# Altium schematic sheet Schem, Transadmittance MPU_Rev_B.SchDoc
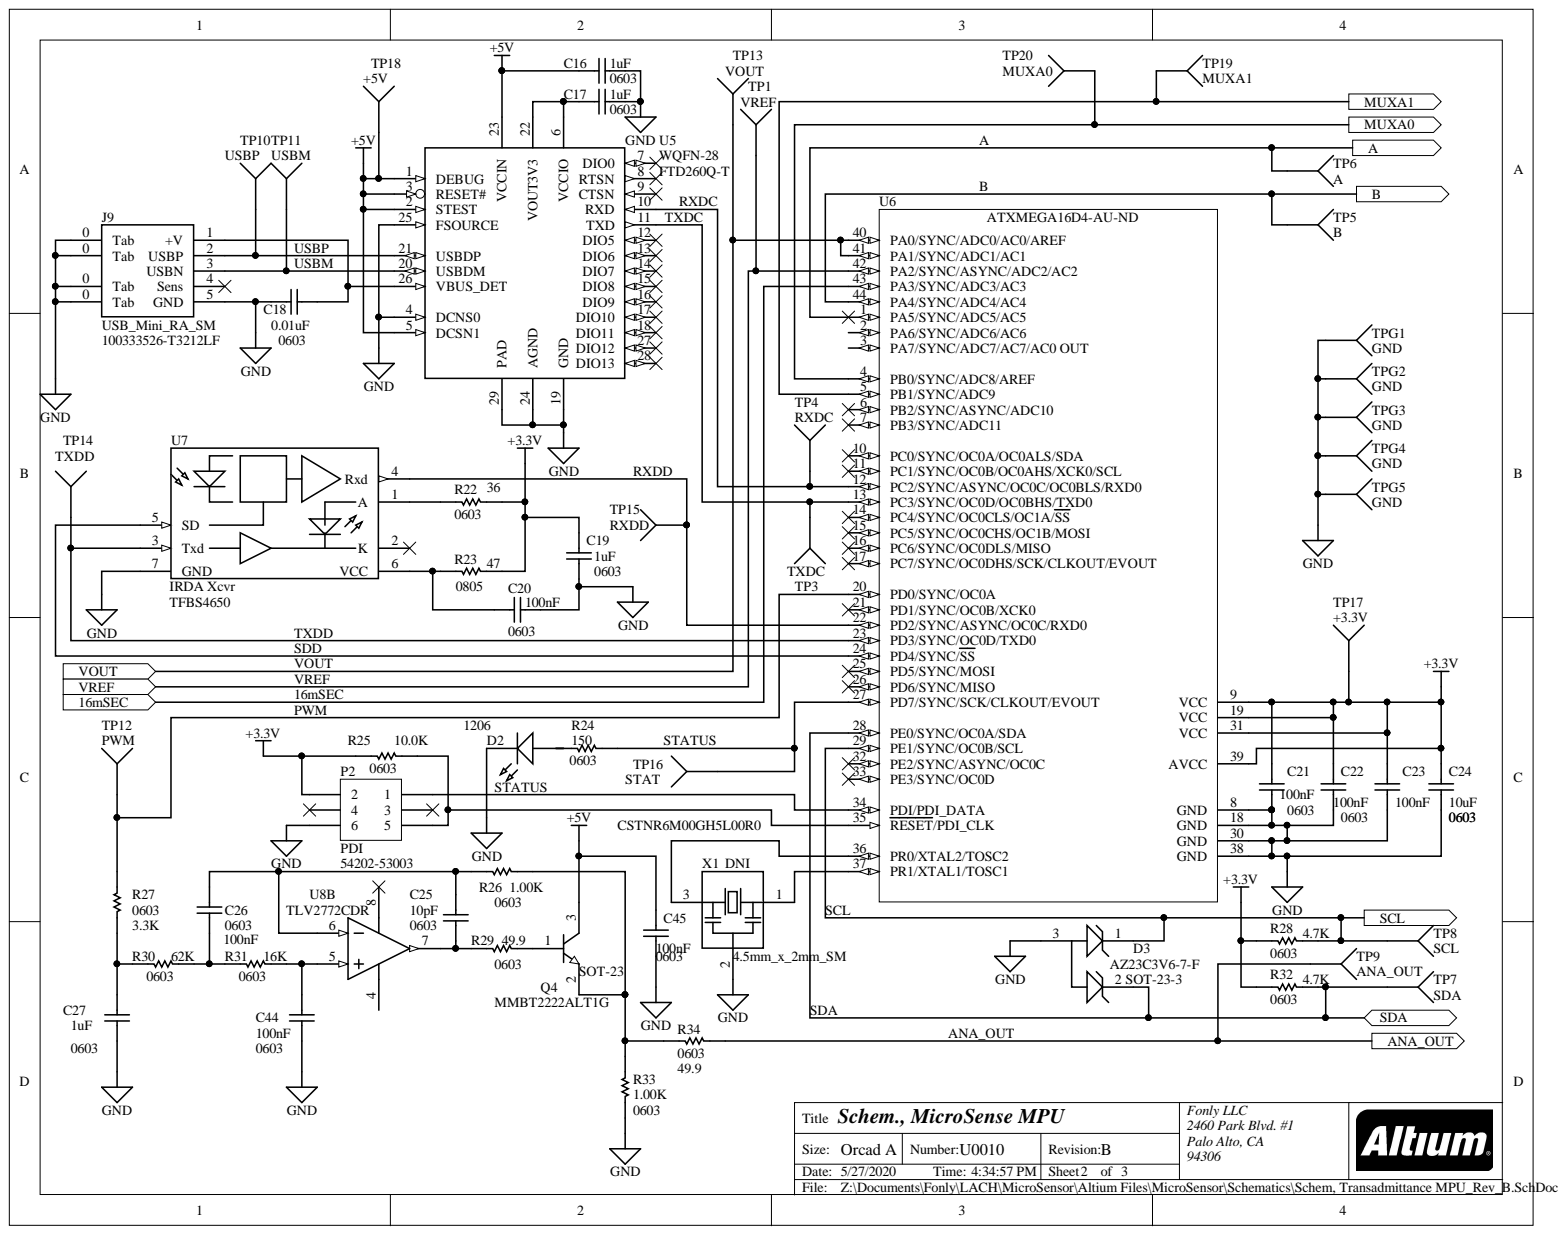
[diagram p0: Schem, Transadmittance MPU_Rev_B.SchDoc]

## Parts
C16  0603  value 1uF  [1608[0603]]
C17  0603  value 1uF  [1608[0603]]
C18  0603  value 0.01uF  [1608[0603]]
C19  0603  value 1uF  [1608[0603]]
C20  0603  value 100nF  [1608[0603]]
C21  0603  value 100nF  [1608[0603]]
C22  0603  value 100nF  [1608[0603]]
C23  0603  value 100nF  [1608[0603]]
C24  0603  value 10uF  [1608[0603]]
C25  0603  value 10pF  [1608[0603]]
C26  0603  value 100nF  [1608[0603]]
C27  0603  value 1uF  [1608[0603]]
C44  0603  value 100nF  [1608[0603]]
C45  0603  value 100nF  [1608[0603]]
D2  1206  value STATUS  [3.5X2.8X1.9]
D3  SOT-23-3  MFG_P/N: AZ23C3V6-7-F  [SOT-23_L]
J9  USB_Mini_RA_SM  MFG_P/N: 100333526-T3212LF  [USB-B-Mini_SM_RA]
P2  PDI  MFG_P/N: 54202-53003  [FCI54202]
Q4  SOT-23  MFG_P/N: MMBT2222ALT1G  [SOT-23_N]
R22  0603  value 36  [RESC1608L]
R23  0805  value 47  [6-0805_M]
R24  0603  value 150  [RESC1608L]
R25  0603  value 10.0K  [RESC1608L]
R26  0603  value 1.00K  [RESC1608L]
R27  0603  value 3.3K  [RESC1608L]
R28  0603  value 4.7K  [RESC1608L]
R29  0603  value 49.9  [RESC1608L]
R30  0603  value 62K  [RESC1608L]
R31  0603  value 16K  [RESC1608L]
R32  0603  value 4.7K  [RESC1608L]
R33  0603  value 1.00K  [RESC1608L]
R34  0603  value 49.9  [RESC1608L]
TP1  VREF  [PIN1]
TP3  TXDC  [PIN1]
TP4  RXDC  [PIN1]
TP5  B  [PIN1]
TP6  A  [PIN1]
TP7  SDA  [PIN1]
TP8  SCL  [PIN1]
TP9  ANA_OUT  [PIN1]
TP10  USBP  [PIN1]
TP11  USBM  [PIN1]
TP12  PWM  [PIN1]
TP13  VOUT  [PIN1]
TP14  TXDD  [PIN1]
TP15  RXDD  [PIN1]
TP16  STAT  [PIN1]
TP17  +3.3V  [PIN1]
TP18  +5V  [PIN1]
TP19  MUXA1  [PIN1]
TP20  MUXA0  [PIN1]
TPG1  GND  [PIN1]
TPG2  GND  [PIN1]
TPG3  GND  [PIN1]
TPG4  GND  [PIN1]
TPG5  GND  [PIN1]
U5  WQFN-28  MFG_P/N: FTD260Q-T  [WQFN-28]
U6  Vendor P/N: ATXMEGA16D4-AU-ND  [44A_N]  (hidden comment: ATxmega16D4-AU)
U7  IRDA Xcvr  [TFBS4650]
U8  TLV2772CDR  [D0008A_N]  (part B)
X1  4.5mm_x_2mm_SM  value DNI  [CSTNR]

## Ports
16mSEC, A, ANA_OUT, B, MUXA0, MUXA1, SCL, SDA, VOUT, VREF

## Nets
+3.3V: C19.1 C21.1 C22.1 C23.1 C24.1 P2.2 R22.1 R23.1 R25.1 R28.2 R32.2 TP17.1 U6.9(VCC) U6.19(VCC) U6.31(VCC) U6.39(AVCC)
+5V: C16.2 C45.1 Q4.3 TP18.1 U5.1(DEBUG) U5.2(STEST) U5.3(RESET#) U5.5(DCSN1) U5.23(VCCIN)
GND: C16.1 C17.2 C18.2 C19.2 C20.2 C21.2 C22.2 C23.2 C24.2 C27.2 C44.2 C45.2 D2.2 D3.3 J9.0(Tab) J9.5(GND) P2.6 R33.1 TPG1.1 TPG2.1 TPG3.1 TPG4.1 TPG5.1 U5.4(DCNS0) U5.19(GND) U5.24(AGND) U5.25(FSOURCE) U5.29(PAD) U6.8(GND) U6.18(GND) U6.30(GND) U6.38(GND) U7.7(GND) X1.2
16mSEC: U6.43(PA3/SYNC/ADC3/AC3) ; port 16mSEC
A: TP6.1 U6.1(PA5/SYNC/ADC5/AC5) ; port A
ANA_OUT: R34.1 TP9.1 ; port ANA_OUT
B: TP5.1 U6.44(PA4/SYNC/ADC4/AC4) ; port B
MUXA0: TP20.1 U6.4(PB0/SYNC/ADC8/AREF) ; port MUXA0
MUXA1: TP19.1 U6.5(PB1/SYNC/ADC9) ; port MUXA1
PWM: R27.2 TP12.1 U6.20(PD0/SYNC/OC0A)
RXDC: TP4.1 U5.10(RXD) U6.12(PC2/SYNC/ASYNC/OC0C/OC0BLS/RXD0)
RXDD: TP15.1 U6.22(PD2/SYNC/ASYNC/OC0C/RXD0) U7.4(Rxd)
SCL: D3.1 R28.1 TP8.1 U6.29(PE1/SYNC/OC0B/SCL) ; port SCL
SDA: D3.2 R32.1 TP7.1 U6.28(PE0/SYNC/OC0A/SDA) ; port SDA
SDD: U6.24(PD4/SYNC/SS) U7.5(SD)
STATUS: R24.2 TP16.1 U6.27(PD7/SYNC/SCK/CLKOUT/EVOUT)
TXDC: TP3.1 U5.11(TXD) U6.13(PC3/SYNC/OC0D/OC0BHS/TXD0)
TXDD: TP14.1 U6.23(PD3/SYNC/OC0D/TXD0) U7.3(Txd)
USBM: J9.3(USBN) TP11.1 U5.20(USBDM)
USBP: J9.2(USBP) TP10.1 U5.21(USBDP)
VOUT: TP13.1 U6.40(PA0/SYNC/ADC0/AC0/AREF) U6.41(PA1/SYNC/ADC1/AC1) ; port VOUT
VREF: TP1.1 U6.42(PA2/SYNC/ASYNC/ADC2/AC2) ; port VREF
NetC17_1: C17.1 U5.6(VCCIO) U5.22(VOUT3V3)
NetC18_1: C18.1 J9.1(+V) U5.26(VBUS_DET)
NetC20_1: C20.1 R23.2 U7.6(VCC)
NetC25_1: C25.1 C26.1 R26.2 U8.6
NetC25_2: C25.2 R29.2 U8.7
NetC26_2: C26.2 R30.1 R31.2
NetC27_1: C27.1 R27.1 R30.2
NetC44_1: C44.1 R31.1 U8.5
NetD2_1: D2.1 R24.1
NetP2_1: P2.1 U6.34(PDI/PDI_DATA)
NetP2_5: P2.5 R25.2 U6.35(RESET/PDI_CLK)
NetQ4_1: Q4.1 R29.1
NetQ4_2: Q4.2 R26.1 R33.2 R34.2
NetR22_2: R22.2 U7.1(A)
NetU6_36: U6.36(PR0/XTAL2/TOSC2) X1.3
NetU6_37: U6.37(PR1/XTAL1/TOSC1) X1.1

Not connected: J9 4(Sens); P2 3 4; U5 7(DIO0) 8(RTSN) 9(CTSN) 12(DIO5) 13(DIO6) 14(DIO7) 15(DIO8) 16(DIO9) 17(DIO10) 18(DIO11) 27(DIO12) 28(DIO13); U6 2(PA6/SYNC/ADC6/AC6) 3(PA7/SYNC/ADC7/AC7/AC0_OUT) 6(PB2/SYNC/ASYNC/ADC10) 7(PB3/SYNC/ADC11) 10(PC0/SYNC/OC0A/OC0ALS/SDA) 11(PC1/SYNC/OC0B/OC0AHS/XCK0/SCL) 14(PC4/SYNC/OC0CLS/OC1A/SS) 15(PC5/SYNC/OC0CHS/OC1B/MOSI) 16(PC6/SYNC/OC0DLS/MISO) 17(PC7/SYNC/OC0DHS/SCK/CLKOUT/EVOUT) 21(PD1/SYNC/OC0B/XCK0) 25(PD5/SYNC/MOSI) 26(PD6/SYNC/MISO) 32(PE2/SYNC/ASYNC/OC0C) 33(PE3/SYNC/OC0D); U7 2(K); U8 4 8

## Library data of the parts
C16 = Cap Semi: Capacitor Ceramic, SMD, 0603, .01uF, 16V, 10%; MFG: Kemet; MFG_P/N: C0603C105M3RACTU; Vendor: DigiKey; Vendor P/N: 399-7377-1-ND; Component Kind: Standard; Library Name: Miscellaneous Devices.SchLib; Signal Integrity: Cap Semi; Simulation: CAP
C17 C19 = Cap Semi: Capacitor (Semiconductor SIM Model); MFG: Kemet; MFG_P/N: C0603C105M3RACTU; Vendor: DigiKey; Vendor P/N: 399-7377-1-ND; Component Kind: Standard; Library Name: Miscellaneous Devices.SchLib; Signal Integrity: Cap Semi; Simulation: CAP
C18 = Cap Semi: Capacitor Ceramic, SMD, X7R, 0603, .01uF, 16V, 10%; MFG: Kemet; MFG_P/N: C0603C103K4RACAUTO; Vendor: DigiKey; Vendor P/N: 399-12987-1-ND; Component Kind: Standard; Library Name: Miscellaneous Devices.SchLib; Signal Integrity: Cap Semi; Simulation: CAP
C20-C23 C26 C44 C45 = Cap Semi: Capacitor Ceramic, SMD, 0603, 0.1uF, 16V, 10%; MFG: Samsung; MFG_P/N: CL10B104KO8NNWC; Vendor: DigiKey; Vendor P/N: 1276-1939-1-ND; Component Kind: Standard; Library Name: Miscellaneous Devices.SchLib; Signal Integrity: Cap Semi; Simulation: CAP
C24 = Cap Semi: Capacitor (Semiconductor SIM Model); MFG: AVX; MFG_P/N: 0603ZD106KAT2A; Vendor: DigiKey; Vendor P/N: 478-10766-1-ND; Component Kind: Standard; Library Name: Miscellaneous Devices.SchLib; Signal Integrity: Cap Semi; Simulation: CAP
C25 = Cap Semi: Capacitor Ceramic, SMD, 0603, 0.1uF, 16V, 10%; MFG: Samsung; MFG_P/N: C0603C100K3GACTU; Vendor: DigiKey; Vendor P/N: 399-8979-1-ND; Component Kind: Standard; Library Name: Miscellaneous Devices.SchLib; Signal Integrity: Cap Semi; Simulation: CAP
C27 = Cap Semi: Capacitor Ceramic, SMD, 0603, 0.1uF, 16V, 10%; MFG: Kemet; MFG_P/N: C0603C105M3RACTU; Vendor: DigiKey; Vendor P/N: 399-7377-1-ND; Component Kind: Standard; Library Name: Miscellaneous Devices.SchLib; Signal Integrity: Cap Semi; Simulation: CAP
D2 = LED3: Green LED, 1206; MFG: Vishay; MFG_P/N: LTST-C150GKT; Vendor: DigiKey; Vendor P/N: 160-1169-1-ND; Component Kind: Standard; Library Name: Miscellaneous Devices.SchLib; Signal Integrity: LED; Simulation: LED3
D3 = Dual_Zener_Com_A_SOT-23: Dual Zener diode array, SOT-23-3; MFG: Diodes Inc.; Vendor: DighiKey; Vendor P/N: AZ23C3V6-FDICT-ND; Component Kind: Standard; Library Name: Miscellaneous Devices.SchLib
J9 = USB-Mini-B-SM-RA: Recp., USB Mini B, RA SM, 3.95mm H; MFG: FCI; Vendor: DigiKey; Vendor P/N: 609-4700-2-ND; Component Kind: Standard; Library Name: Current Miscellaneous Connectors.SchLib
P2 = Header 3X2: Header, 3-Pin, Dual row, 0.1" ctrs, Vert., SM; MFG: FCI; Vendor: DigiKey; Vendor P/N: 609-6366-ND; Component Kind: Standard; Library Name: Current Miscellaneous Connectors.SchLib; Signal Integrity: Connector
Q4 = NPN: NPN Bipolar Transistor; MFG: National; Vendor: DigiKey; Vendor P/N: MMBT2222ALT1GOSCT-ND; Component Kind: Standard; Library Name: Miscellaneous Devices.SchLib; Signal Integrity: NPN; Simulation: NPN
R22 = Res1: Resistor; MFG: Panasonic; MFG_P/N: ERJ-3EKF36R0V; Vendor: DigiKey; Vendor P/N: P36.0HCT-ND; Component Kind: Standard; Library Name: Miscellaneous Devices.SchLib; Signal Integrity: Res; Simulation: RESISTOR
R23 = Res1: Resistor; MFG: Rohm; MFG_P/N: KTR10EZPJ470; Vendor: DigiKey; Vendor P/N: RHM47BCT-ND; Component Kind: Standard; Library Name: Miscellaneous Devices.SchLib; Signal Integrity: Res; Simulation: RESISTOR
R24 = Res1: Resistor; MFG: Panasonic; MFG_P/N: ERJ-3EKF1500V; Vendor: DigiKey; Vendor P/N: P150HCT-ND; Component Kind: Standard; Library Name: Miscellaneous Devices.SchLib; Signal Integrity: Res; Simulation: RESISTOR
R25 R26 R33 = Res1: Resistor; MFG: Panasonic; MFG_P/N: ERJ-3EKF1001V; Vendor: DigiKey; Vendor P/N: P1.00KHCT-ND; Component Kind: Standard; Library Name: Miscellaneous Devices.SchLib; Signal Integrity: Res; Simulation: RESISTOR
R27 = Res1: Resistor; MFG: Panasonic; MFG_P/N: ERJ-3EKF3301V; Vendor: DigiKey; Vendor P/N: P3.30KHCT-ND; Component Kind: Standard; Library Name: Miscellaneous Devices.SchLib; Signal Integrity: Res; Simulation: RESISTOR
R28 R32 = Res1: Resistor; MFG: Panasonic; MFG_P/N: ERJ-3EKF4701V; Vendor: DigiKey; Vendor P/N: P4.70KHCT-ND; Component Kind: Standard; Library Name: Miscellaneous Devices.SchLib; Signal Integrity: Res; Simulation: RESISTOR
R29 R34 = Res1: Resistor; MFG: Panasonic; MFG_P/N: ERJ-3EKF49R9V; Vendor: DigiKey; Vendor P/N: P49.9HCT-ND; Component Kind: Standard; Library Name: Miscellaneous Devices.SchLib; Signal Integrity: Res; Simulation: RESISTOR
R30 = Res1: Resistor; MFG: Panasonic; MFG_P/N: ERJ-3EKF6202V; Vendor: DigiKey; Vendor P/N: P62.0KHCT-ND; Component Kind: Standard; Library Name: Miscellaneous Devices.SchLib; Signal Integrity: Res; Simulation: RESISTOR
R31 = Res1: Resistor; MFG: Panasonic; MFG_P/N: ERJ-3EKF1602V; Vendor: DigiKey; Vendor P/N: P16.0KHCT-ND; Component Kind: Standard; Library Name: Miscellaneous Devices.SchLib; Signal Integrity: Res; Simulation: RESISTOR
TP1 TP3-TP20 TPG1-TPG5 = Socket: Component Kind: Standard; Description: Socket; LatestRevisionDate: 17-Jul-2002; LatestRevisionNote: Re-released for DXP Platform.; Library Name: Current Miscellaneous Connectors.SchLib; Signal Integrity: Connector; Publisher: Altium Limited
U5 = FT260Q-T: USB/serial Converter; MFG: FTDI; Vendor: DigiKey; Vendor P/N: 768-1343-1-ND; Component Kind: Standard; Library Name: FTDI Communication USB.SchLib
U6 = ATxmega16D4-AU: 8/16-bit AVR XMEGA D4 Microcontroller, 1.6-3.6V, 16KB + 4KB Flash, 1KB EEPROM, 2KB SRAM, 44-pin TQFP, Industrial Grade (-40°C to 85°C), Pb-Free; MFG: Microchip; Vendor: Digi-Key; Component Kind: Standard; ComponentLink1Description: Manufacturer Link; ComponentLink1URL: http://www.atmel.com/products/avr/default.asp?; ComponentLink2Description: ATxmega128D4/64D4/32D4/16D4 Datasheet; ComponentLink2URL: http://www.atmel.com/dyn/resources/prod_documents/doc8135.pdf; ComponentLink3Description: Atmel.com Home; ComponentLink3URL: http://www.atmel.com; Library Name: Atmel Microcontroller 8-16-Bit AVR XMEGA.IntLib; MFG_P/N: ATXmega16D4-AU
U7 = TFBS4650: IR optical transceiver, SM, RA; MFG: Vishay; Vendor: DigiKey; Vendor P/N: 751-1063-1-ND; Component Kind: Standard; Library Name: Vishay Communication Transceiver.SchLib; MFG_P/N: TFBS4650
U8 = CMP-0272-03014-3: Dual High-Slew-Rate Rail-to-Rail Output Operational Amplifier, 2.5 to 6 V, 0 to 70 degC, 8-pin SOIC (D8), Green (RoHS & no Sb/Br); MFG: TI; Vendor: DigiKey; Vendor P/N: 296-10677-1-ND; Component Kind: Standard; ComponentLink1Description: Manufacturer URL; ComponentLink1URL: http://www.ti.com/; ComponentLink2Description: Datasheet; ComponentLink2URL: http://www.ti.com/lit/ds/symlink/tlv2772.pdf; ComponentLink3Description: Package Specification; ComponentLink3URL: http://www.ti.com/litv/pdf/msoi002j; Library Name: Altium Content Vault; MFG_P/N: TLV2772CDR
X1 = CSTNR_GH5C: Resonator, Cer., int. caps, 4.5mm x 2 mm x 1.1mm; MFG: Murata; MFG_P/N: CSTNR6M00GH5L00R0; Vendor: Mouser; Vendor P/N: 81-CSTNR6M00GH5L00R; Component Kind: Standard; Library Name: Miscellaneous Devices.SchLib
